# Altium schematic sheet Interface.SchDoc
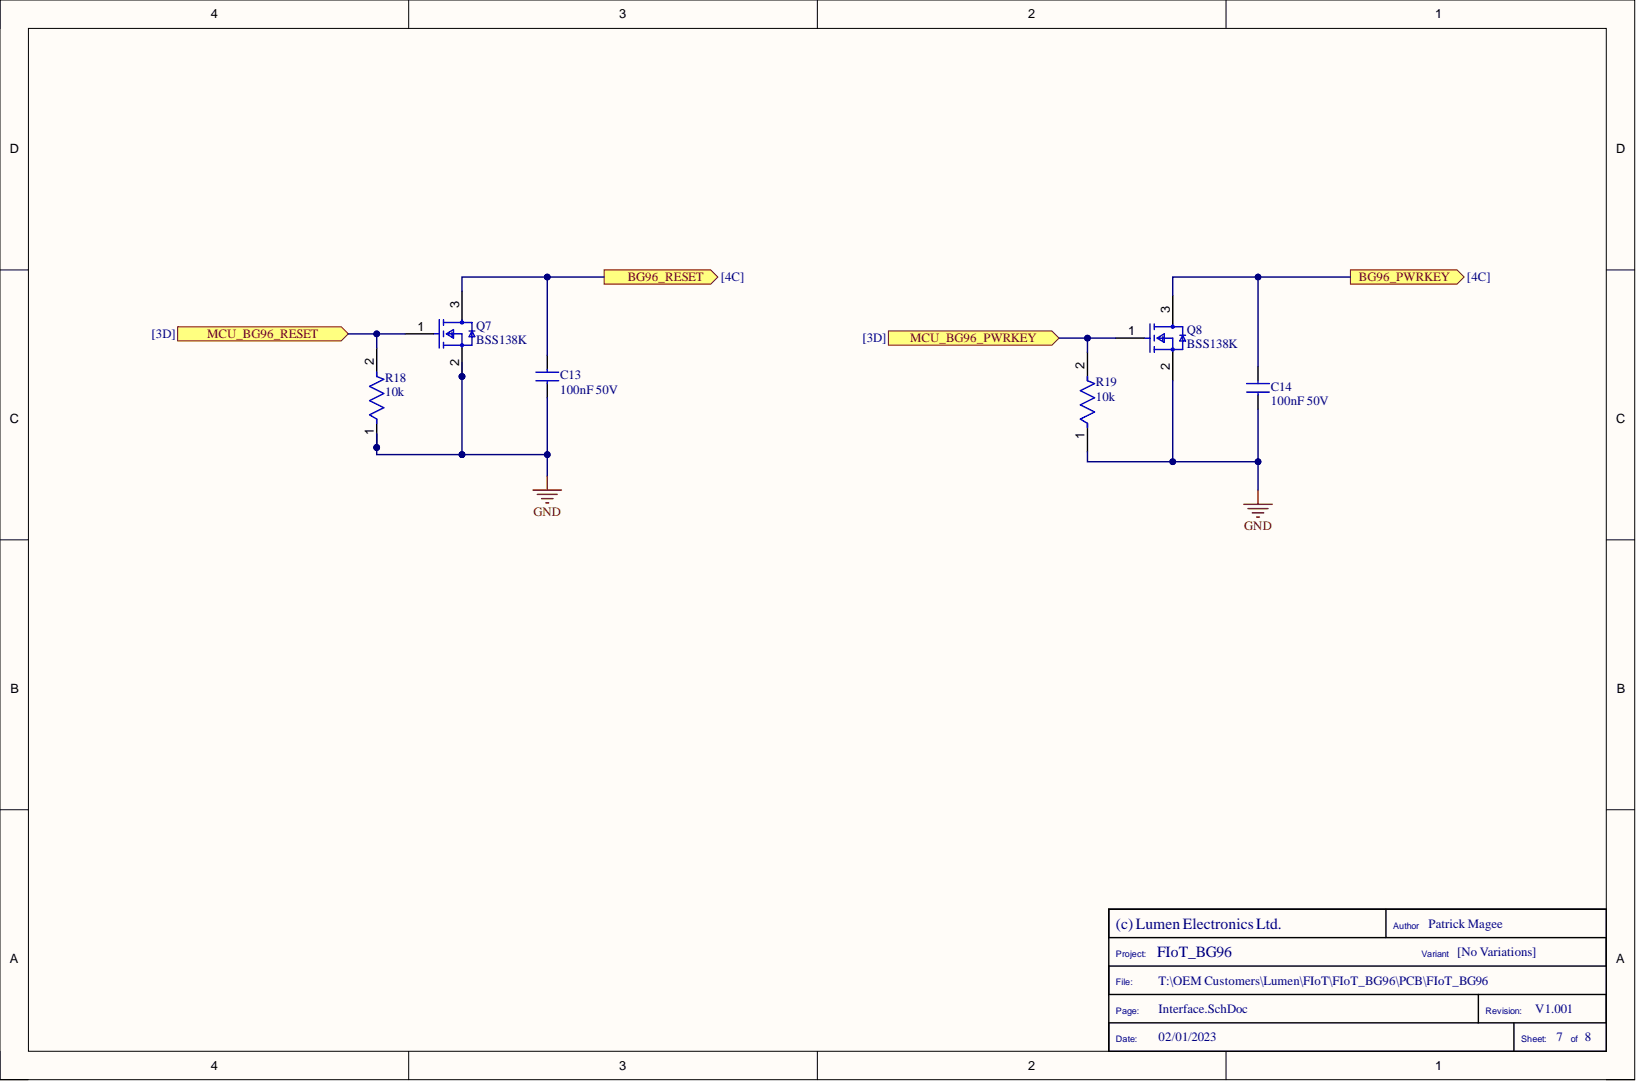
[diagram p0: Interface.SchDoc]

## Parts
C13  value 100nF 50V  [CAPC1005X06N]  (hidden comment: CL05B104KB54PNC)
C14  value 100nF 50V  [CAPC1005X06N]  (hidden comment: CL05B104KB54PNC)
Q7  BSS138K  [FP-318-08-IPC_B]
Q8  BSS138K  [FP-318-08-IPC_B]
R18  Resistance: 10k  [FP-CRCW0402-e3-MFG]  (hidden comment: 0402WGF1002TCE)
R19  Resistance: 10k  [FP-CRCW0402-e3-MFG]  (hidden comment: 0402WGF1002TCE)

## Ports
BG96_PWRKEY, BG96_RESET, MCU_BG96_PWRKEY, MCU_BG96_RESET

## Nets
GND: C13.1 C14.1 Q7.2 Q8.2 R18.1 R19.1
BG96_PWRKEY: C14.2 Q8.3 ; port BG96_PWRKEY
BG96_RESET: C13.2 Q7.3 ; port BG96_RESET
MCU_BG96_PWRKEY: Q8.1 R19.2 ; port MCU_BG96_PWRKEY
MCU_BG96_RESET: Q7.1 R18.2 ; port MCU_BG96_RESET

## Library data of the parts
C13 C14 = CL05B104KB54PNC: 100nF 50V X7R ±10% 0402 MLCC; Manufacturer: TDK; Manufacturer Part Number: C1005C0G1H060C; MFG Part Number: GRM1555C1H6R0CA01D; MFG Name: Murata; Component Kind: Standard; JLC Footprint: CAP-SMD; JLCPCB Part #: C162118; Library Name: FIoT_BG96.SCHLIB; Part Number: GRM1555C1H6R0CA01D; Supplier 1: Digi-Key; Supplier 2: Mouser; Supplier Part Number 1: 1276-CL05B104KB54PNCCT-ND; Supplier Part Number 2: 187-CL05B104KB54PNC
Q7 Q8 = BSS138K: MOSFET N-CH 50V 220MA SOT-23; Manufacturer: ON Semiconductor / Fairchild; Manufacturer Part Number: BSS138; Drain to Source Resistance: 3.5R; Element Configuration: Single; Fall Time: 9ns; Gate to Source Voltage (Vgs): 20V; Input Capacitance: 27pF; Max Power Dissipation: 360mW; Nominal Vgs: 1.3V; Number of Elements: 1; On-State Resistance: 6R; Turn-Off Delay Time: 20ns; Turn-On Delay Time: 2.5ns; Case/Package: SOT-23; Component Kind: Standard; Contact Plating: Tin; Continuous Drain Current (ID): 220mA; Current Rating: 220mA; Drain to Source Breakdown Voltage: 50V; Drain to Source Voltage (Vdss): 50V; Dual Supply Voltage: 50V; Height: 0.93mm; JLC Footprint: SOT-23-3; JLCPCB Part #: C52895; Lead Free: Lead Free; Length: 2.92mm; Library Name: FIoT_BG96.SCHLIB; Max Operating Temperature: 150°C; MFG Name: ON Semiconductor / Fairchild; MFG Part Number: BSS138; Min Operating Temperature: -55°C; Mount: Surface Mount; Number of Pins: 3; Package Quantity: 1; Packaging: Tape and Reel; Power Dissipation: 360mW; Radiation Hardening: No; Rds On Max: 3.5R; REACH SVHC: No SVHC; Rise Time: 9ns; Supplier 1: Digi-Key; Supplier 2: Farnell; Supplier 3: Mouser; Supplier Part Number 1: BSS138K-13DICT-ND; Supplier Part Number 2: 3943470; Supplier Part Number 3: 621-BSS138K-13; Termination: SMD/SMT; Threshold Voltage: 1.3V; Voltage Rating (DC): 50V; Weight: 0.03g; Width: 1.3mm
R18 R19 = 0402WGF1002TCE: Res Thick Film 0402 10K Ohm 1% 0.063W; Manufacturer: Vishay Dale; Manufacturer Part Number: CRCW04021K00FKED; Max Power Dissipation: 63mW; Temperature Coefficient: 100ppm/°C; Voltage Rating: 50V; Case Code (Imperial): 0402; Case Code (Metric): 1005; Case/Package: 0402; Component Kind: Standard; Composition: Thick Film; Contact Plating: Tin; Depth: 0.5mm; Features: Automotive AEC-Q200; Height: 0.35mm; JLC Footprint: R0402; JLCPCB Part #: Not available with JLC; Lead Free: Lead Free; Length: 1mm; Library Name: FIoT_BG96.SCHLIB; Max Operating Temperature: 155°C; MFG Name: Vishay Dale; MFG Part Number: CRCW04021K00FKED; Military Standard: Not; Min Operating Temperature: -55°C; Mount: Surface Mount; Number of Pins: 2; Number of Terminations: 2; Package Quantity: 10; Packaging: Tape and Reel; Power Rating: 63mW; Radiation Hardening: No; Supplier 1: Mouser; Supplier 2: LCSC; Supplier Part Number 1: 303-0402WGF1002TCE; Supplier Part Number 2: C25744; Termination: SMD/SMT; Tolerance: 1%; Voltage Rating (DC): 50V; Weight: 2.3E-05oz; Width: 0.5mm
